# Altium schematic sheet LCD Display and Menu Buttons.SchDoc("LCD Display and Menu Buttons")
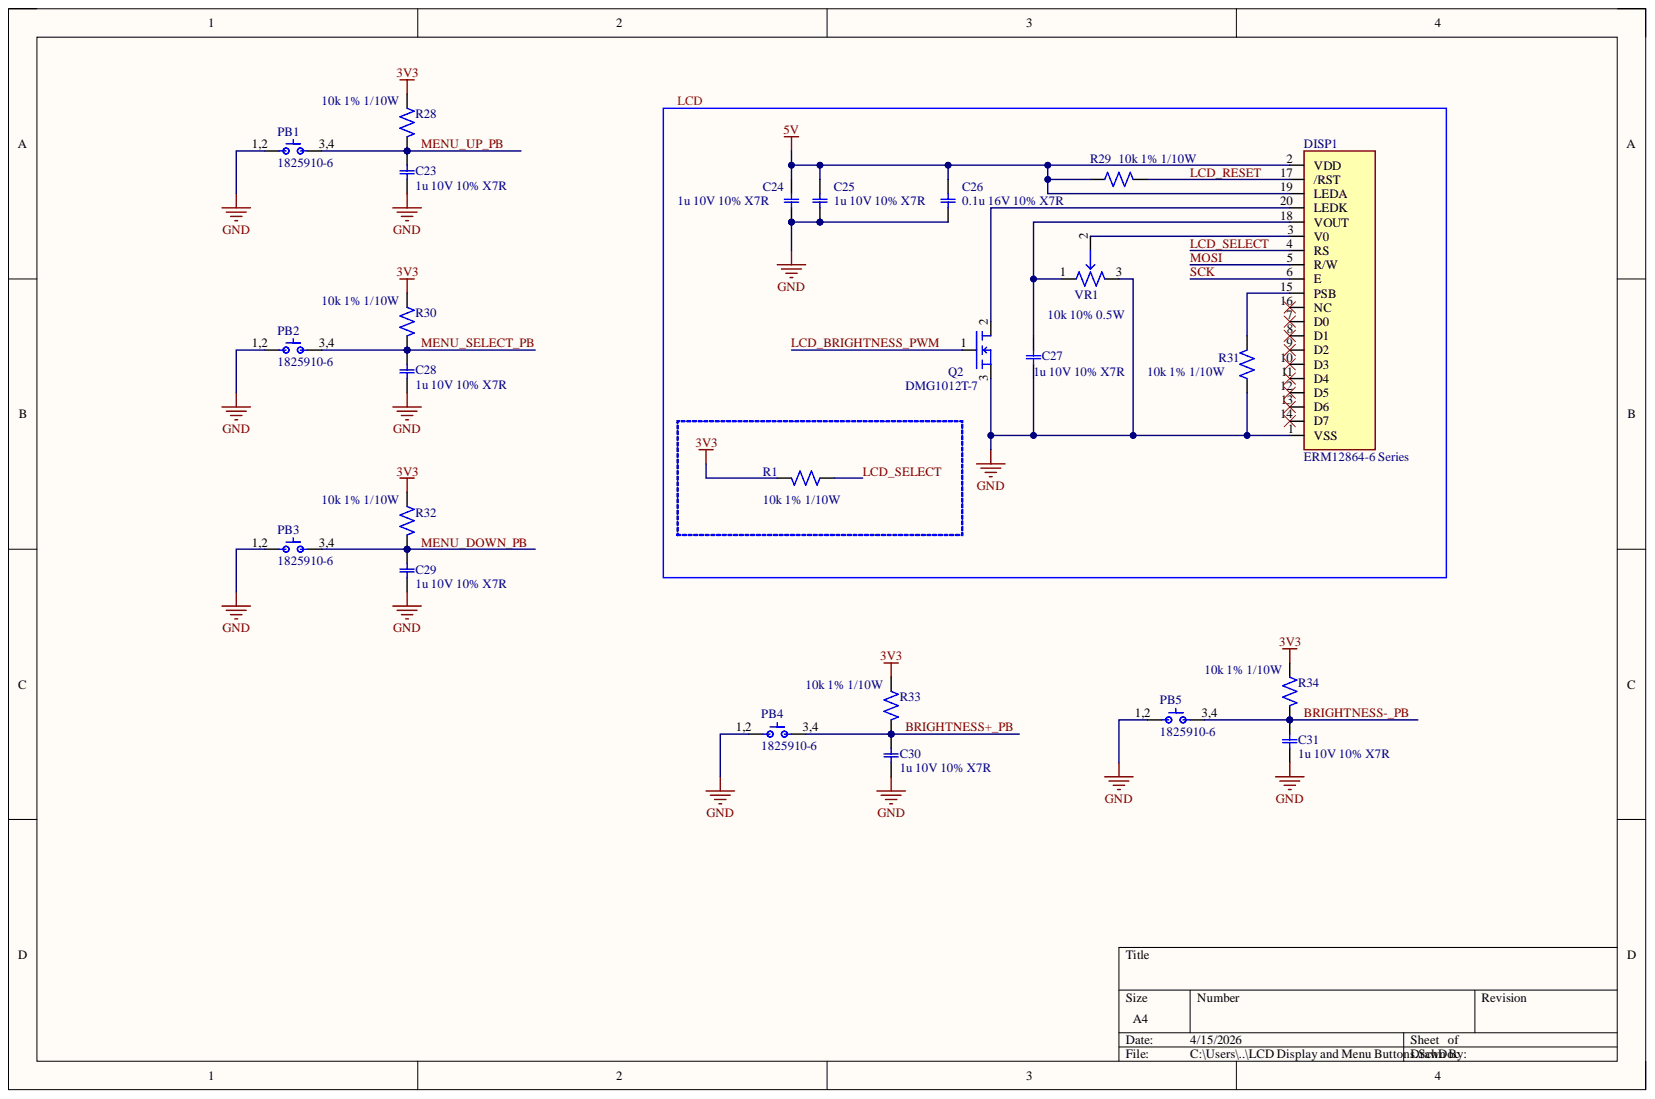
[diagram p0: LCD Display and Menu Buttons.SchDoc]

## Parts
C23  1u 10V 10% X7R  Voltage Rating: 10V  [0603-C]
C24  1u 10V 10% X7R  Dielectric: X7R  [0603-C]
C25  1u 10V 10% X7R  Voltage Rating: 10V  [0603-C]
C26  0.1u 16V 10% X7R  Dielectric: X7R  [0603-C]
C27  1u 10V 10% X7R  Voltage Rating: 10V  [0603-C]
C28  1u 10V 10% X7R  Voltage Rating: 10V  [0603-C]
C29  1u 10V 10% X7R  Voltage Rating: 10V  [0603-C]
C30  1u 10V 10% X7R  Voltage Rating: 10V  [0603-C]
C31  1u 10V 10% X7R  Voltage Rating: 10V  [0603-C]
DISP1  ERM12864-6 Series  [ERM12864-6 Series]
PB1  1825910-6  [1825910-6]
PB2  1825910-6  [1825910-6]
PB3  1825910-6  [1825910-6]
PB4  1825910-6  [1825910-6]
PB5  1825910-6  [1825910-6]
Q2  DMG1012T-7  [DMG1012T-7]
R1  10k 1% 1/10W  Resistance Tolerance: 1%  [0603-R]
R28  10k 1% 1/10W  [0603-R]
R29  10k 1% 1/10W  Resistance Tolerance: 1%  [0603-R]
R30  10k 1% 1/10W  [0603-R]
R31  10k 1% 1/10W  [0603-R]
R32  10k 1% 1/10W  [0603-R]
R33  10k 1% 1/10W  [0603-R]
R34  10k 1% 1/10W  [0603-R]
VR1  10k 10% 0.5W  Tolerance: 10%  [3386F-1-103TLF]

## Nets
3V3: R1.1 R28.2 R30.2 R32.2 R33.2 R34.2
5V: C24.1 C25.1 C26.1 DISP1.2(VDD) DISP1.19(LEDA) R29.2
GND: C23.2 C24.2 C25.2 C26.2 C27.2 C28.2 C29.2 C30.2 C31.2 DISP1.1(VSS) PB1.1,2 PB2.1,2 PB3.1,2 PB4.1,2 PB5.1,2 Q2.3 R31.2 VR1.3
BRIGHTNESS+_PB: C30.1 PB4.3,4 R33.1
BRIGHTNESS-_PB: C31.1 PB5.3,4 R34.1
LCD_BRIGHTNESS_PWM: Q2.1
LCD_RESET: DISP1.17(/RST) R29.1
LCD_SELECT: DISP1.4(RS) R1.2
MENU_DOWN_PB: C29.1 PB3.3,4 R32.1
MENU_SELECT_PB: C28.1 PB2.3,4 R30.1
MENU_UP_PB: C23.1 PB1.3,4 R28.1
MOSI: DISP1.5(R/W)
SCK: DISP1.6(E)
NetC27_1: C27.1 DISP1.18(VOUT) VR1.1
NetDISP1_3: DISP1.3(V0) VR1.2
NetDISP1_15: DISP1.15(PSB) R31.1
NetDISP1_20: DISP1.20(LEDK) Q2.2

Not connected: DISP1 7(D0) 8(D1) 9(D2) 10(D3) 11(D4) 12(D5) 13(D6) 14(D7) 16(NC)

## Library data of the parts
C23-C25 C27-C31 = CC0603KRX7R6BB105: 0603 1 uF 10 V ±10 % Tolerance X7R SMT Multilayer Ceramic Capacitor; Manufacturer: Yageo; Value: 1uF; Case Code (Imperial): 0603; Case Code (Metric): 1608; Case/Package: 0603; Category: Ceramic Capacitors; Component Kind: Standard; ComponentLink1Description: https://datasheet.ciiva.com/26862/getdatasheetpartid-403568-26862819.pdf?src-supplier=Verical; ComponentLink1URL: https://datasheet.ciiva.com/26862/getdatasheetpartid-403568-26862819.pdf?src-supplier=Verical; ComponentLink2Description: https://datasheet.ciiva.com/13208/upy-gphc-x7r-6-3v-to-50v-8-12304-13208872.pdf?src-supplier=Mouser; ComponentLink2URL: https://datasheet.ciiva.com/13208/upy-gphc-x7r-6-3v-to-50v-8-12304-13208872.pdf?src-supplier=Mouser; Depth: 0.8mm; Halogen Free: 5694HalogenFree; Lead Free: Lead Free; Length: 1.6mm; Manufacturer Part Number: CC0603KRX7R6BB105; Material: Ceramic; Max Operating Temperature: 125°C; Min Operating Temperature: -55°C; Mounting Technology: Surface Mount; Packaging: Tape and Reel; Pricing: 1=0.13, 10=0.072, 100=0.0434, 1000=0.02889 (USD); Radiation Hardening: No; RoHS: Yes; RoHS Compliant: Yes; Series: CC; Stock: 262345; Supplier 1: Digikey; Supplier Part Number 1: 311-1796-1-ND; Termination: SMD/SMT; Tolerance: 10%; Voltage Rating (DC): 10V; Weight: 7.1E-05oz; Width: 0.8mm; Library Name: tpmania.SchLib
  C23 C25 C27-C31: Dielectric: X7R
  C24: Voltage Rating: 10V
C26 = CC0603KRX7R7BB104: 0603 0.1 uF 16 V ±10 % Tolerance X7R SMT Multilayer Ceramic Capacitor; Manufacturer: Yageo; Insulation Resistance: 100GR; Value: 100nF; Case Code (Imperial): 0603; Case Code (Metric): 1608; Case/Package: 0603; Category: Ceramic Capacitors; Component Kind: Standard; ComponentLink1Description: https://datasheet.ciiva.com/11786/0900766b80d84f1d-11786077.pdf?src-supplier=Rs; ComponentLink1URL: https://datasheet.ciiva.com/11786/0900766b80d84f1d-11786077.pdf?src-supplier=Rs; ComponentLink2Description: https://datasheet.ciiva.com/144/mlccorderingcode-144182.pdf?src-supplier=Digikey; ComponentLink2URL: https://datasheet.ciiva.com/144/mlccorderingcode-144182.pdf?src-supplier=Digikey; ComponentLink3Description: https://datasheet.ciiva.com/144/mlccorderingcode-144183.pdf?src-supplier=Digikey; ComponentLink3URL: https://datasheet.ciiva.com/144/mlccorderingcode-144183.pdf?src-supplier=Digikey; ComponentLink4Description: https://datasheet.ciiva.com/144/mlccorderingcode-144184.pdf?src-supplier=Digikey; ComponentLink4URL: https://datasheet.ciiva.com/144/mlccorderingcode-144184.pdf?src-supplier=Digikey; ComponentLink5Description: https://datasheet.ciiva.com/7978/1489800-7978141.pdf?src-supplier=Element14; ComponentLink5URL: https://datasheet.ciiva.com/7978/1489800-7978141.pdf?src-supplier=Element14; ComponentLink6Description: https://datasheet.ciiva.com/11464/upy-gphc-x7r-6-3v-to-50v-8-11464735.pdf?src-supplier=Avnet; ComponentLink6URL: https://datasheet.ciiva.com/11464/upy-gphc-x7r-6-3v-to-50v-8-11464735.pdf?src-supplier=Avnet; ComponentLink7Description: https://datasheet.ciiva.com/28450/1489800-28450552.pdf?src-supplier=Element14; ComponentLink7URL: https://datasheet.ciiva.com/28450/1489800-28450552.pdf?src-supplier=Element14; ComponentLink8Description: https://datasheet.ciiva.com/46430/1734573-46430156.pdf?src-supplier=Element14; ComponentLink8URL: https://datasheet.ciiva.com/46430/1734573-46430156.pdf?src-supplier=Element14; ComponentLink9Description: https://datasheet.ciiva.com/8033/1734573-8033797.pdf?src-supplier=Element14; ComponentLink9URL: https://datasheet.ciiva.com/8033/1734573-8033797.pdf?src-supplier=Element14; ComponentLink10Description: https://datasheet.ciiva.com/8033/70765-8033799.pdf?src-supplier=Element14; ComponentLink10URL: https://datasheet.ciiva.com/8033/70765-8033799.pdf?src-supplier=Element14; ComponentLink11Description: https://datasheet.ciiva.com/15165/getdatasheetpartid-63554-15165387.pdf?src-supplier=Verical; ComponentLink11URL: https://datasheet.ciiva.com/15165/getdatasheetpartid-63554-15165387.pdf?src-supplier=Verical; ComponentLink12Description: https://datasheet.ciiva.com/13395/upy-gphc-x7r-6-3v-to-50v-8-13395674.pdf?src-supplier=Mouser; ComponentLink12URL: https://datasheet.ciiva.com/13395/upy-gphc-x7r-6-3v-to-50v-8-13395674.pdf?src-supplier=Mouser; Depth: 0.8mm; Halogen Free: Halogen Free; Height: 0.8mm; Lead Free: Lead Free; Length: 1.6mm; Manufacturer Part Number: CC0603KRX7R7BB104; Material: Ceramic; Max Operating Temperature: 125°C; Min Operating Temperature: -55°C; Mounting Technology: Surface Mount; Package Quantity: 1; Packaging: Tape and Reel; Pins: 2; Pricing: 1=0.08, 10=0.014, 50=0.012, 100=0.0096, 500=0.0072, 1000=0.0064 (USD); Radiation Hardening: No; Reach SVHC Compliant: No; RoHS: Yes; RoHS Compliant: Yes; Series: CC; Stock: 7111175; Supplier 1: Digikey; Supplier Part Number 1: 311-1088-1-ND; Termination: SMD/SMT; Thickness: 0.035inch; Tolerance: 10%; Voltage: 16V; Voltage Rating: 16V; Voltage Rating (DC): 16V; Width: 0.031inch; Library Name: tpmania.SchLib
DISP1 = ERM12864-6 Series: LCD graphic module display; Component Kind: Standard; Library Name: ENGG3800 schematic library.SchLib
PB1-PB5 = 1825910-6: Switch Tactile OFF (ON) SPST Round Button PC Pins 0.05A 24VDC 1.57N Thru-Hole Loose; Manufacturer: TE Connectivity Alcoswitch; Actuator Length: 0.055inch; Actuator Material: PBT; Actuator Type: Standard; Contact Current Rating: 50mA; Illumination Type: Non-Illuminated,Illuminated; Insulation Resistance: 100MR; Max Voltage Rating (DC): 24V; Mechanical Life: 100000Cycles; Number of Positions: 2NUMBER; Operating Force: 160gf; Switch Function: Off-Mom; Switch Travel: 0.25mm; Category: Pushbutton Switches; Color: 46Colour; Component Kind: Standard; ComponentLink1Description: https://datasheet.ciiva.com/30199/1825910-6rqpn1825910-6-30199520.pdf?src-supplier=Onlinecomponents.com; ComponentLink1URL: https://datasheet.ciiva.com/30199/1825910-6rqpn1825910-6-30199520.pdf?src-supplier=Onlinecomponents.com; ComponentLink2Description: https://datasheet.ciiva.com/15184/getdatasheetpartid-395915-15184509.pdf?src-supplier=Verical; ComponentLink2URL: https://datasheet.ciiva.com/15184/getdatasheetpartid-395915-15184509.pdf?src-supplier=Verical; Contact Material: Copper Alloy; Contact Plating: Silver; Current Rating: 50mA; ELV: 5681ELVCompliant; Lead Free: 5697LeadFree; Length: 5.99mm; Manufacturer Part Number: 1825910-6; Material: 4261ConductorMaterial; Max Current Rating: 50mA; Max Operating Temperature: 85°C; Min Operating Temperature: -35°C; Mounting Technology: Through Hole; Packaging: Loose Piece; Pricing: 1=0.06097, 10=0.05768, 30=0.05616, 50=0.05548, 100=0.05519 (USD); Reach SVHC Compliant: Unknown; RoHS: Yes; RoHS Compliant: Yes; Series: FSMJ; Stock: 140583; Supplier 1: Avnet; Supplier Part Number 1: 1825910-6; Termination: PC Pins; Throw Configuration: SPST; Type: Switch; Width: 6mm; Library Name: tpmania.SchLib
Q2 = DMG1012T-7: Transistor: N-MOSFET; unipolar; 20V; 0.63A; 0.4ohm; 0.28W; -55+150 deg.C; SMD; SOT523; AEC-Q100; Manufacturer: Diodes; Continuous Drain Current (ID): 630mA; Drain to Source Resistance: 300mR; Drain-source On Resistance-Max: 400MR; Fall Time: 12.3ns; FET Type: 1 N-Channel; Gate to Source Voltage (Vgs): 6V; Input Capacitance: 60.67pF; Max Power Dissipation: 280mW; Number of Channels: 1; Polarity: N-CHANNEL; Rise Time: 7.4ns; Threshold Voltage: 1V; Turn-Off Delay Time: 26.7ns; Turn-On Delay Time: 5.1ns; Case/Package: SOT-523; Category: MOSFETs; Component Kind: Standard; ComponentLink1Description: https://datasheet.ciiva.com/934/ds31783-934512.pdf?src-supplier=Digikey; ComponentLink1URL: https://datasheet.ciiva.com/934/ds31783-934512.pdf?src-supplier=Digikey; ComponentLink2Description: https://datasheet.ciiva.com/4442/ds31783-44973-4442944.pdf?src-supplier=Mouser; ComponentLink2URL: https://datasheet.ciiva.com/4442/ds31783-44973-4442944.pdf?src-supplier=Mouser; ComponentLink3Description: https://datasheet.ciiva.com/6383/ds31783-6383803.pdf?src-supplier=Digikey; ComponentLink3URL: https://datasheet.ciiva.com/6383/ds31783-6383803.pdf?src-supplier=Digikey; ComponentLink4Description: https://datasheet.ciiva.com/934/ds31783-934511.pdf?src-supplier=Digikey; ComponentLink4URL: https://datasheet.ciiva.com/934/ds31783-934511.pdf?src-supplier=Digikey; ComponentLink5Description: https://datasheet.ciiva.com/11174/ds31783-11174965.pdf?src-supplier=Avnet; ComponentLink5URL: https://datasheet.ciiva.com/11174/ds31783-11174965.pdf?src-supplier=Avnet; Drain to Source Voltage (Vdss): 20V; Height: 0.8mm; Lead Free: Lead Free; Length: 1.7mm; Manufacturer Part Number: DMG1012T-7; Max Operating Temperature: 150°C; Min Operating Temperature: -55°C; Mounting Technology: Surface Mount; Number of Elements: 1; Package Quantity: 3000; Packaging: Tape and Reel; Pins: 3; Power Dissipation: 280mW; Pricing: 3000=0.0191, 12000=0.0186, 30000=0.0182, 75000=0.0179, 120000=0.0174 (USD); Radiation Hardening: No; Rds On Max: 400mR; Reach SVHC Compliant: No; RoHS: Yes; RoHS Compliant: Yes; Series: -; Stock: 1974000; Supplier 1: Digikey; Supplier Part Number 1: DMG1012T-7DICT-ND; Weight: 7.1E-05oz; Width: 0.85mm; Library Name: tpmania.SchLib
R1 R28-R34 = RK73H1JTTD1002F: Resistor Thick Film 0603 10K Ohm 1% 1/10W ±100ppm/°C SMD Punched Paper Tape and Reel; Manufacturer: KOA Speer; Max Power Dissipation: 100mW; Value: 10kR; Voltage Rating: 50V; Case Code (Imperial): 0603; Case Code (Metric): 1608; Case/Package: 0603; Category: Chip SMD Resistors; Component Kind: Standard; ComponentLink1Description: https://datasheet.ciiva.com/5556/rk73h-5556565.pdf?src-supplier=Mouser; ComponentLink1URL: https://datasheet.ciiva.com/5556/rk73h-5556565.pdf?src-supplier=Mouser; ComponentLink2Description: https://datasheet.ciiva.com/48426/rk73h-series-eng-jap-tds-48426520.pdf?src-supplier=Distrelec; ComponentLink2URL: https://datasheet.ciiva.com/48426/rk73h-series-eng-jap-tds-48426520.pdf?src-supplier=Distrelec; ComponentLink3Description: https://datasheet.ciiva.com/7773/549017-7773368.pdf?src-supplier=Element14; ComponentLink3URL: https://datasheet.ciiva.com/7773/549017-7773368.pdf?src-supplier=Element14; ComponentLink4Description: https://datasheet.ciiva.com/15159/getdatasheetpartid-89857-15159884.pdf?src-supplier=Verical; ComponentLink4URL: https://datasheet.ciiva.com/15159/getdatasheetpartid-89857-15159884.pdf?src-supplier=Verical; Construction: Rectangular; Depth: 0.8mm; Features: Moisture Resistant,Automotive AEC-Q200; Height: 0.45mm; Lead Free: Lead Free; Length: 1.6mm; Manufacturer Part Number: RK73H1JTTD1002F; Max Operating Temperature: 155°C; Military Standard: Not; Min Operating Temperature: -55°C; Number of Terminations: 2; Package Quantity: 5000; Packaging: Tape and Reel; Pins: 2; Power Rating: 100mW; Pricing: 1=0.1, 10=0.026, 100=0.0124, 1000=0.0068, 2500=0.00557 (USD); Ratings: AEC-Q200; Reach SVHC Compliant: Unknown; Resistor Type: Thick Film; RoHS: Yes; RoHS Compliant: Yes; Series: RK73H; Stock: 1507817; Supplier 1: Digikey; Supplier Part Number 1: 2019-RK73H1JTTD1002FCT-ND; Temperature Coefficient: 100ppm/°C; Termination: SMD/SMT; Tolerance: 1%; Voltage Rating (DC): 50V; Width: 0.8mm; Library Name: tpmania.SchLib
  R28 R30-R34: Resistance Tolerance: 1%
VR1 = 3386F-1-103TLF: Cermet Not Linear TRIMMER Trimmer Potentiometer 卤10% 280deg 500mW 85C; Manufacturer: Bourns; Lead Diameter: 510µm; Lead Length: 5.97mm; Number of Turns: 1; Temperature Coefficient: 100ppm/°C; Value: 10kΩ; Voltage Rating: 300V; Category: Variable Resistors and Potentiometers; Color: Blue; Component Kind: Standard; ComponentLink1Description: https://datasheet.octopart.com:443/3386F-1-103TLF-Bourns-datasheet-175646159.pdf?src-supplier=IHS; ComponentLink1URL: https://datasheet.octopart.com:443/3386F-1-103TLF-Bourns-datasheet-175646159.pdf?src-supplier=IHS; ComponentLink2Description: https://datasheet.octopart.com:443/3386F-1-103TLF-Bourns-datasheet-62341472.pdf?src-supplier=Burklin+Elektronik; ComponentLink2URL: https://datasheet.octopart.com:443/3386F-1-103TLF-Bourns-datasheet-62341472.pdf?src-supplier=Burklin+Elektronik; ComponentLink3Description: https://datasheet.octopart.com:443/3386F-1-103TLF-Bourns-datasheet-177039049.pdf?src-supplier=Burklin+Elektronik; ComponentLink3URL: https://datasheet.octopart.com:443/3386F-1-103TLF-Bourns-datasheet-177039049.pdf?src-supplier=Burklin+Elektronik; ComponentLink4Description: https://datasheet.octopart.com:443/3386F-1-103TLF-Bourns-datasheet-10840548.pdf?src-supplier=RS+(Formerly+Allied+Electronics); ComponentLink4URL: https://datasheet.octopart.com:443/3386F-1-103TLF-Bourns-datasheet-10840548.pdf?src-supplier=RS+(Formerly+Allied+Electronics); ComponentLink5Description: https://datasheet.octopart.com:443/3386F-1-103TLF-Bourns-datasheet-25360175.pdf?src-supplier=RS+(Formerly+Allied+Electronics); ComponentLink5URL: https://datasheet.octopart.com:443/3386F-1-103TLF-Bourns-datasheet-25360175.pdf?src-supplier=RS+(Formerly+Allied+Electronics); ComponentLink6Description: https://datasheet.octopart.com/3386F-1-103TLF-Bourns-datasheet-375.pdf; ComponentLink6URL: https://datasheet.octopart.com/3386F-1-103TLF-Bourns-datasheet-375.pdf; ComponentLink7Description: https://datasheet.octopart.com/3386F-1-103TLF-Bourns-datasheet-8361462.pdf; ComponentLink7URL: https://datasheet.octopart.com/3386F-1-103TLF-Bourns-datasheet-8361462.pdf; ComponentLink8Description: https://datasheet.octopart.com:443/3386F-1-103TLF-Bourns-datasheet-147334286.pdf?src-supplier=Electro+Sonic; ComponentLink8URL: https://datasheet.octopart.com:443/3386F-1-103TLF-Bourns-datasheet-147334286.pdf?src-supplier=Electro+Sonic; ComponentLink9Description: https://datasheet.octopart.com:443/3386F-1-103TLF-Bourns-datasheet-8714847.pdf?src-supplier=Factory+Futures; ComponentLink9URL: https://datasheet.octopart.com:443/3386F-1-103TLF-Bourns-datasheet-8714847.pdf?src-supplier=Factory+Futures; ComponentLink10Description: https://datasheet.octopart.com:443/3386F-1-103TLF-Bourns-datasheet-20860029.pdf?src-supplier=Newark; ComponentLink10URL: https://datasheet.octopart.com:443/3386F-1-103TLF-Bourns-datasheet-20860029.pdf?src-supplier=Newark; ComponentLink11Description: https://datasheet.octopart.com:443/3386F-1-103TLF-Bourns-datasheet-17172198.pdf?src-supplier=Farnell; ComponentLink11URL: https://datasheet.octopart.com:443/3386F-1-103TLF-Bourns-datasheet-17172198.pdf?src-supplier=Farnell; ComponentLink12Description: http://sigma.octopart.com:80/conflict_mineral_statement/Bourns-company-36.pdf?src-supplier=Compliance+Statements; ComponentLink12URL: http://sigma.octopart.com:80/conflict_mineral_statement/Bourns-company-36.pdf?src-supplier=Compliance+Statements; Composition: Cermet; Country of Origin: Costa Rica; Depth: 9.53mm; Export Control Classification Number (ECCN) Code: EAR99; Harmonized Tariff Schedule (HTS) Code: 8533.40.80.50; Height: 4.83mm; Introduction Date: 2010-08-11; Lead Free: Lead Free; Length: 9.53mm; Library Name: ENGG3800 schematic library.SchLib; Lifecycle Status: Production; Manufacturer Part Number: 3386F-1-103TLF; Max Operating Temperature: 125°C; Max Power Dissipation: 500mW; Military Standard: Not; Min Operating Temperature: -55°C; Mounting Technology: Through Hole; Number of Terminals: 3; Power Dissipation: 500mW; Power Rating: 500mW; Pricing: 1=2.42, 10=1.96, 25=1.92, 50=1.87, 100=1.74, 500=1.57, 1000=1.52 (USD); Radiation Hardening: No; REACH SVHC: No; RoHS: Compliant; Stock: 325; Supplier 1: Digikey; Supplier Part Number 1: 3386F-103TLF-ND; Taper: Linear; Termination: Pin; Voltage Rating (DC): 300V; Weight: 850.485694mg; Width: 9.53mm
